# Altium schematic sheet USB_SD.SchDoc
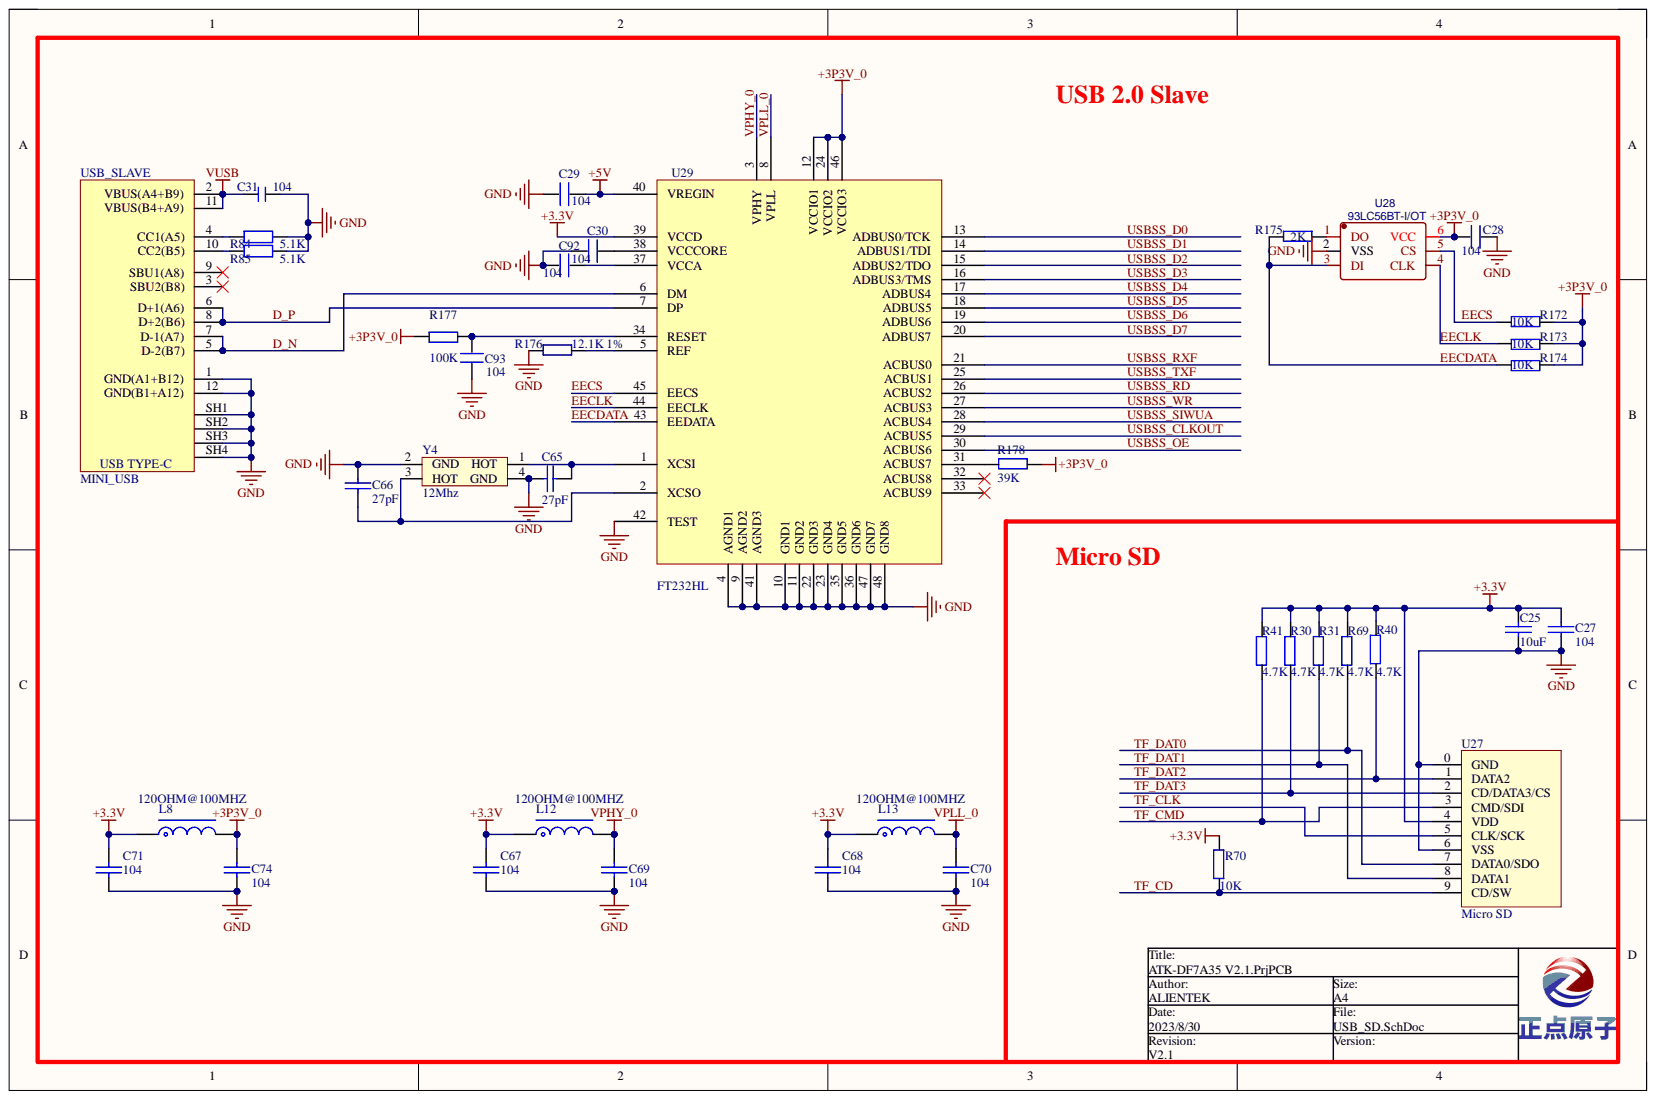
[diagram p0: USB_SD.SchDoc]

## Parts
C25  10uF  [0603P]
C27  104  [0603P]
C28  104  [0603P]
C29  104  [0603P]
C30  104  [0603P]
C31  104  [0603P]
C65  27pF  [0603P]
C66  27pF  [0603P]
C67  104  [0603P]
C68  104  [0603P]
C69  104  [0603P]
C70  104  [0603P]
C71  104  [0603P]
C74  104  [0603P]
C92  104  [0603P]
C93  104  [0603P]
L8  120OHM@100MHZ  [0603P]
L12  120OHM@100MHZ  [0603P]
L13  120OHM@100MHZ  [0603P]
R30  4.7K  [0603P]
R31  4.7K  [0603P]
R40  4.7K  [0603P]
R41  4.7K  [0603P]
R69  4.7K  [0603P]
R70  10K  [0603P]
R84  5.1K  [0402]
R85  5.1K  [0402]
R172  10K  [0603P]
R173  10K  [0603P]
R174  10K  [0603P]
R175  2K  [0603P]
R176  12.1K 1%  [0603P]
R177  100K  [0603P]
R178  39K  [0603P]
U27  Micro SD  [SD-MICRO-TF]
U28  93LC56BT-I/OT  [SOT-23-6]
U29  FT232HL  [LQFP-48]
USB_SLAVE  MINI_USB  [USB TYPE-C - 10*9]
Y4  12Mhz  [XTAL3225]

## Nets
+3.3V: C25.1 C27.1 C67.1 C68.1 C71.1 L8.1 L12.1 L13.1 R30.1 R31.1 R40.1 R41.1 R69.1 R70.1 U27.4(VDD) U29.39(VCCD)
+3P3V_0: C28.2 C74.1 L8.2 R172.1 R173.2 R174.2 R177.1 R178.1 U28.6(VCC) U29.12(VCCIO1) U29.24(VCCIO2) U29.46(VCCIO3)
+5V: C29.1 U29.40(VREGIN)
GND: C25.2 C27.2 C28.1 C29.2 C30.2 C31.1 C65.1 C66.1 C67.2 C68.2 C69.2 C70.2 C71.2 C74.2 C92.2 C93.2 R84.1 R85.1 R176.2 U27.0(GND) U27.6(VSS) U28.2(VSS) U29.4(AGND1) U29.9(AGND2) U29.10(GND1) U29.11(GND2) U29.22(GND3) U29.23(GND4) U29.35(GND5) U29.36(GND6) U29.41(AGND3) U29.42(TEST) U29.47(GND7) U29.48(GND8) USB_SLAVE.1(GND(A1+B12)) USB_SLAVE.12(GND(B1+A12)) USB_SLAVE.SH1(Shield1) USB_SLAVE.SH2(Shield2) USB_SLAVE.SH3(Shield3) USB_SLAVE.SH4(Shield4) Y4.2(GND) Y4.4(GND)
VUSB: C31.2 USB_SLAVE.2(VBUS(A4+B9)) USB_SLAVE.11(VBUS(B4+A9))
D_N: U29.6(DM) USB_SLAVE.5(D-2(B7)) USB_SLAVE.7(D-1(A7))
D_P: U29.7(DP) USB_SLAVE.6(D+1(A6)) USB_SLAVE.8(D+2(B6))
EECDATA: R174.1 R175.2 U28.3(DI) U29.43(EEDATA)
EECLK: R173.1 U28.4(CLK) U29.44(EECLK)
EECS: R172.2 U28.5(CS) U29.45(EECS)
TF_CD: R70.2 U27.9(CD/SW)
TF_CLK: U27.5(CLK/SCK)
TF_CMD: R41.2 U27.3(CMD/SDI)
TF_DAT0: R69.2 U27.7(DATA0/SDO)
TF_DAT1: R31.2 U27.8(DATA1)
TF_DAT2: R40.2 U27.1(DATA2)
TF_DAT3: R30.2 U27.2(CD/DATA3/CS)
USBSS_CLKOUT: U29.29(ACBUS5)
USBSS_D0: U29.13(ADBUS0/TCK)
USBSS_D1: U29.14(ADBUS1/TDI)
USBSS_D2: U29.15(ADBUS2/TDO)
USBSS_D3: U29.16(ADBUS3/TMS)
USBSS_D4: U29.17(ADBUS4)
USBSS_D5: U29.18(ADBUS5)
USBSS_D6: U29.19(ADBUS6)
USBSS_D7: U29.20(ADBUS7)
USBSS_OE: U29.30(ACBUS6)
USBSS_RD: U29.26(ACBUS2)
USBSS_RXF: U29.21(ACBUS0)
USBSS_SIWUA: U29.28(ACBUS4)
USBSS_TXF: U29.25(ACBUS1)
USBSS_WR: U29.27(ACBUS3)
VPHY_0: C69.1 L12.2 U29.3(VPHY)
VPLL_0: C70.1 L13.2 U29.8(VPLL)
NetC30_1: C30.1 U29.38(VCCCORE)
NetC65_2: C65.2 U29.1(XCSI) Y4.1(HOT)
NetC66_2: C66.2 U29.2(XCSO) Y4.3(HOT)
NetC92_1: C92.1 U29.37(VCCA)
NetC93_1: C93.1 R177.2 U29.34(RESET)
NetR84_2: R84.2 USB_SLAVE.4(CC1(A5))
NetR85_2: R85.2 USB_SLAVE.10(CC2(B5))
NetR175_1: R175.1 U28.1(DO)
NetR176_1: R176.1 U29.5(REF)
NetR178_2: R178.2 U29.31(ACBUS7)

Not connected: U29 32(ACBUS8) 33(ACBUS9); USB_SLAVE 3(SBU2(B8)) 9(SBU1(A8))

## Library data of the parts
C25 C27 = CAP: Component Kind: Standard
  C25: Library Name: ZYNQ.SCHLIB
C28-C30 C92 C93 = C: Component Kind: Standard; Library Name: ALIENTEK_COMPONENT.IntLib
C31 = C: 无极性贴片电容; 版权归属: 源创客; BOM名称: 无极性贴片电容; Component Kind: Standard; Library Name: 电阻-电容-电感.SchLib; 学习资源: www.ooocke.com
C65 C66 = CAP_27pF: Component Kind: Standard; Library Name: ZYNQ.SCHLIB
C67-C71 C74 = CAP_104_0.1uf: Component Kind: Standard; Library Name: ZYNQ.SCHLIB
L8 L12 L13 = L-CDRH: 功率屏蔽电感; 版权归属: 源创客; BOM名称: 功率屏蔽电感; Component Kind: Standard; Library Name: 电阻-电容-电感.SchLib; 学习资源: www.ooocke.com
R30 R31 R40 R41 R69 R70 R172-R178 = R: Component Kind: Standard; Library Name: MYCOMLIB.IntLib
R84 R85 = R: 贴片电阻; 版权归属: 源创客; BOM名称: 贴片电阻; Component Kind: Standard; Library Name: 电阻-电容-电感.SchLib; 学习资源: www.ooocke.com
U27 = Micro SD: Micro SD卡; 版权归属: 源创客; BOM名称: Micro SD卡; Component Kind: Standard; Library Name: ZYNQ.SCHLIB; 学习资源: www.ooocke.com
U28 = 93LC56BT-I/OT: BOM_Manufacturer: Microchip Tech; Contributor: LCSC; SMT Type: Extend; BOM_LCSC Assembly: Yes; BOM_Manufacturer Part: 93LC56BT-I/OT; BOM_Supplier: LCSC; BOM_Supplier Part: C190271; Component Kind: Standard; spicePre: U; spiceSymbolName: 93LC56BT-I/OT
USB_SLAVE = USB Type-C: 12P TypeC 母座; Component Kind: Standard; Library Name: Lycreturn.IntLib
Y4 = XTAL_3225: 3225贴片无源晶振; Component Kind: Standard; Library Name: ZYNQ.SCHLIB
U29 = FT232HL: Component Kind: Standard; Library Name: ZYNQ.SCHLIB
